# Altium schematic sheet TP_STM32.SchDoc
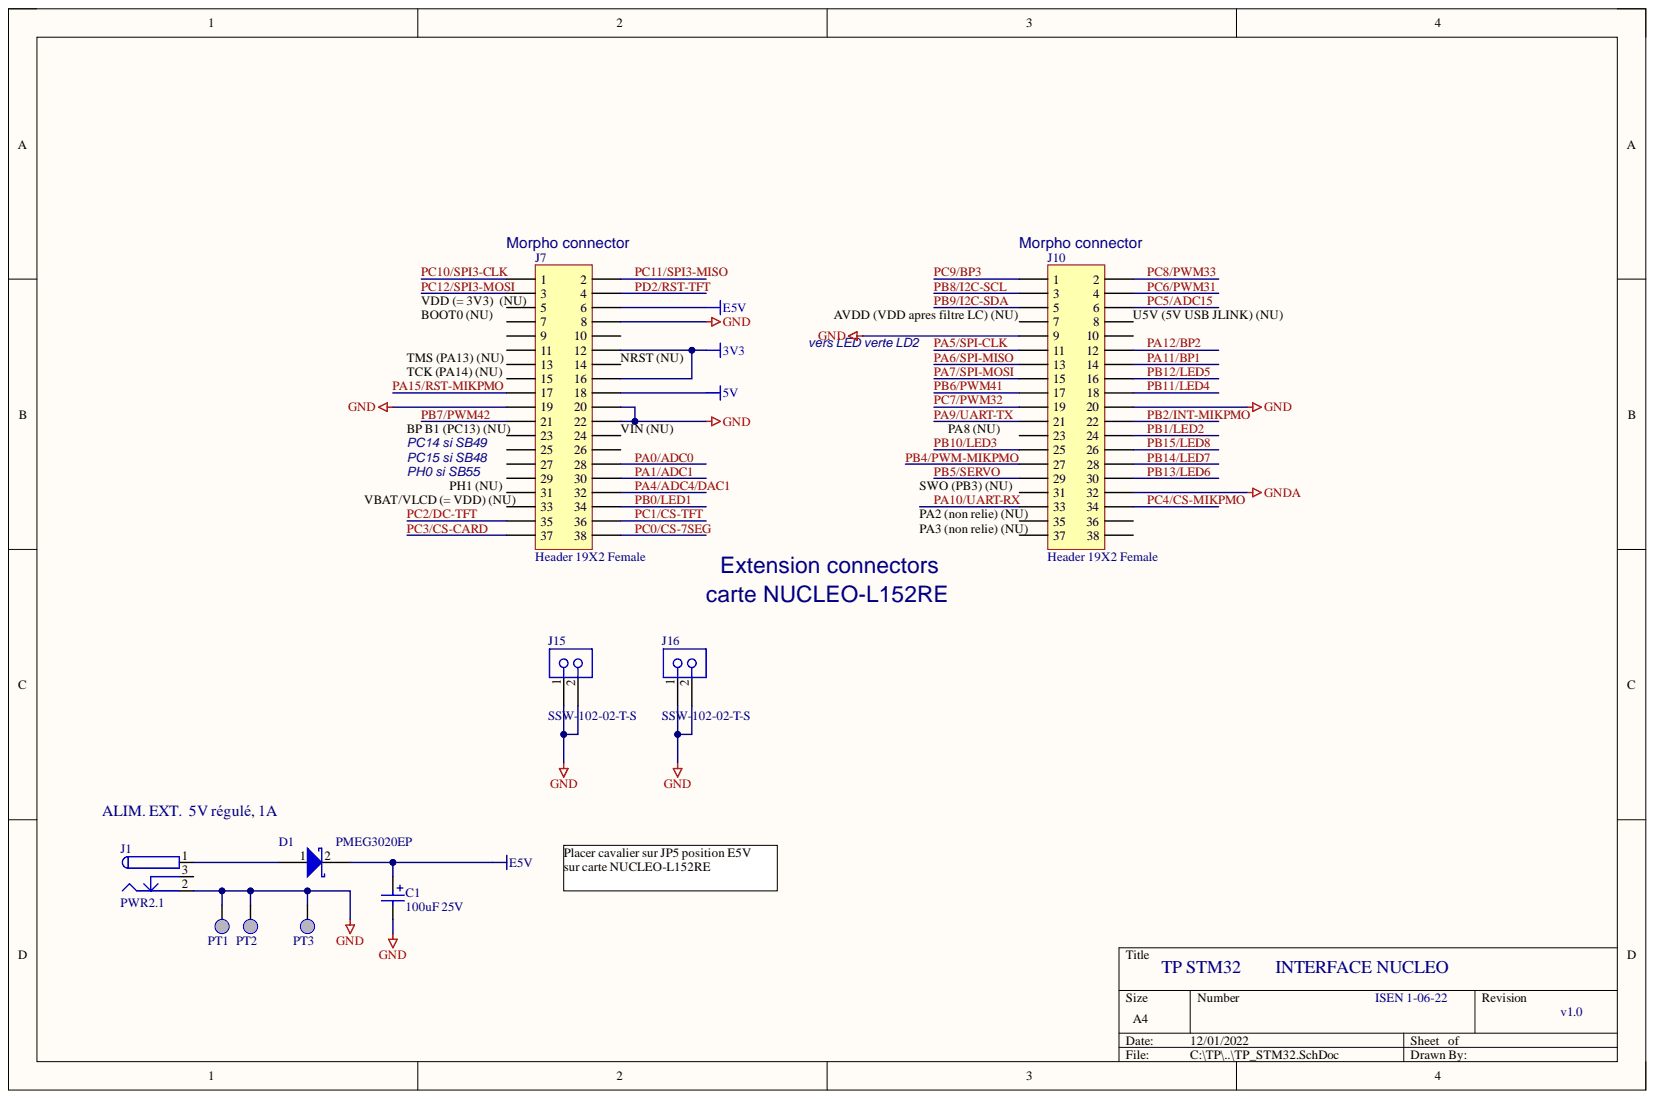
[diagram p0: TP_STM32.SchDoc]

## Parts
C1  100uF 25V  [RB0.1/0.2]
D1  PMEG3020EP  [PMEG3020]
J1  PWR2.1  [PWRJACK-2.1MM]
J7  Header 19X2 Female  [HE14-2X19F]
J10  Header 19X2 Female  [HE14-2X19F]
J15  SSW-102-02-T-S  [SMTC-SSW-102-02-X-S]
J16  SSW-102-02-T-S  [SMTC-SSW-102-02-X-S]
PT1  PTTEST GND  [PTEST]  (value not drawn)
PT2  PTTEST GND  [PTEST]  (value not drawn)
PT3  PTTEST GND  [PTEST]  (value not drawn)

## Nets
3V3: J7.12 J7.16
5V: J7.18
E5V: C1.1 D1.2 J7.6
GND: C1.2 J1.2 J7.8 J7.19 J7.20 J7.22 J10.9 J10.20 J15.1 J15.2 J16.1 J16.2 PT1.1 PT2.1 PT3.1
GNDA: J10.32
PA0/ADC0: J7.28
PA1/ADC1: J7.30
PA4/ADC4/DAC1: J7.32
PA5/SPI-CLK: J10.11
PA6/SPI-MISO: J10.13
PA7/SPI-MOSI: J10.15
PA9/UART-TX: J10.21
PA10/UART-RX: J10.33
PA11/BP1: J10.14
PA12/BP2: J10.12
PA15/RST-MIKPMO: J7.17
PB0/LED1: J7.34
PB1/LED2: J10.24
PB2/INT-MIKPMO: J10.22
PB4/PWM-MIKPMO: J10.27
PB5/SERVO: J10.29
PB6/PWM41: J10.17
PB7/PWM42: J7.21
PB8/I2C-SCL: J10.3
PB9/I2C-SDA: J10.5
PB10/LED3: J10.25
PB11/LED4: J10.18
PB12/LED5: J10.16
PB13/LED6: J10.30
PB14/LED7: J10.28
PB15/LED8: J10.26
PC0/CS-7SEG: J7.38
PC1/CS-TFT: J7.36
PC2/DC-TFT: J7.35
PC3/CS-CARD: J7.37
PC4/CS-MIKPMO: J10.34
PC5/ADC15: J10.6
PC6/PWM31: J10.4
PC7/PWM32: J10.19
PC8/PWM33: J10.2
PC9/BP3: J10.1
PC10/SPI3-CLK: J7.1
PC11/SPI3-MISO: J7.2
PC12/SPI3-MOSI: J7.3
PD2/RST-TFT: J7.4
NetD1_1: D1.1 J1.1

Not connected: J1 3; J7 5 7 9 10 11 13 14 15 23 24 25 26 27 29 31 33; J10 7 8 10 23 31 35 36 37 38

## Library data of the parts
C1 = Cap Pol1: Capacitor Electrolytic Radial pas 2.54mm; Manufacturer: Generic Components; Class I: Passive; Class II: Capacitor; Component Kind: Standard; Library Name: Miscellaneous Devices.IntLib; Published: 8-Jun-2000; Revision: July-2002: Re-released for DXP Platform.; Signal Integrity: Cap Pol; Simulation: CAP; Value: 100uF 25V; Publisher: Altium Limited
D1 = D Schottky: Schottky Diode SMD; Manufacturer: NEXPERIA; Code_JEDEC: DO-214-AA; Component Kind: Standard; LatestRevisionDate: 17-Jul-2002; LatestRevisionNote: Re-released for DXP Platform.; Library Name: Miscellaneous Devices.IntLib; Manufacturer Part Number: PMEG3020EP; PackageDescription: DO-214-AA/SMB; 2 C-Bend Leads; Body 5.3 x 3.6 mm, inc. leads (LxW); PackageReference: SMB; Published: 8-Jun-2000; Signal Integrity: D Schottky; Simulation: SKYDIODE; Publisher: Altium Limited
J1 = PWR2.5: Embase femelle JACK DC 2.1mm; Component Kind: Standard; ComponentLink1Description: Manufacturer Link; ComponentLink1URL: http://domino.kycon.com/internet/Products.html; DatasheetDocument: 09-May-2002; Library Name: Miscellaneous Connectors.IntLib; Published: 17-Dec-2003; Signal Integrity: Connector; Publisher: Altium Limited
J7 J10 = Header 19X2: Header 19X2 Female 2.54mm; Manufacturer: SAMTEC; Manufacturer Part Number: SSW-119-01-T-D; Component Kind: Standard; Library Name: Nucleo_64_1.SCHLIB; Revision: 17-Jul-2002: Re-released for DXP Platform.; Signal Integrity: Connector; Publisher: Altium Limited
J15 J16 = CMP-1023-00323-1: SQ Post Socket, Through-hole, Vertical, -55 to 105 degC, 2.54 mm Pitch, 2-Pin, Female, RoHS; Manufacturer: Samtec; Pitch: 2.54 mm; Component Kind: Standard; ComponentLink1Description: Manufacturer URL; ComponentLink1URL: http://www.samtec.com; ComponentLink2Description: Datasheet; ComponentLink2URL: http://www.samtec.com/documents/webfiles/pdf/SSW_TH.PDF; ComponentLink3Description: Package Specification; ComponentLink3URL: http://www.samtec.com/documents/webfiles/cpdf/SSW-1XX-XX-XXX-X-XX-XXX-XX-MKT.pdf; DatasheetVersion: F-214; Library Name: Altium Content Vault; Mounting Technology: Through-hole; Orientation: Vertical; PackageDescription: Assembly, Socket Strip .016 X .031 Tail; PackageReference: SSW-102-02-X-S; PackageVersion: CA, 6/1987; PartNumber: SSW-102-02-T-S; RoHS: True; Temperature Range: -55 to 105 degC
PT1-PT3 = POINT-TEST: POINT TEST; Manufacturer: VERO; Manufacturer Part Number: WP6820; Class I: Passive; Component Kind: Standard; Library Name: STM8S.SCHLIB; Published: 15/12/03; Revision: 1.0; Publisher: ISEN BREST
